# Altium schematic sheet z-probe.SchDoc
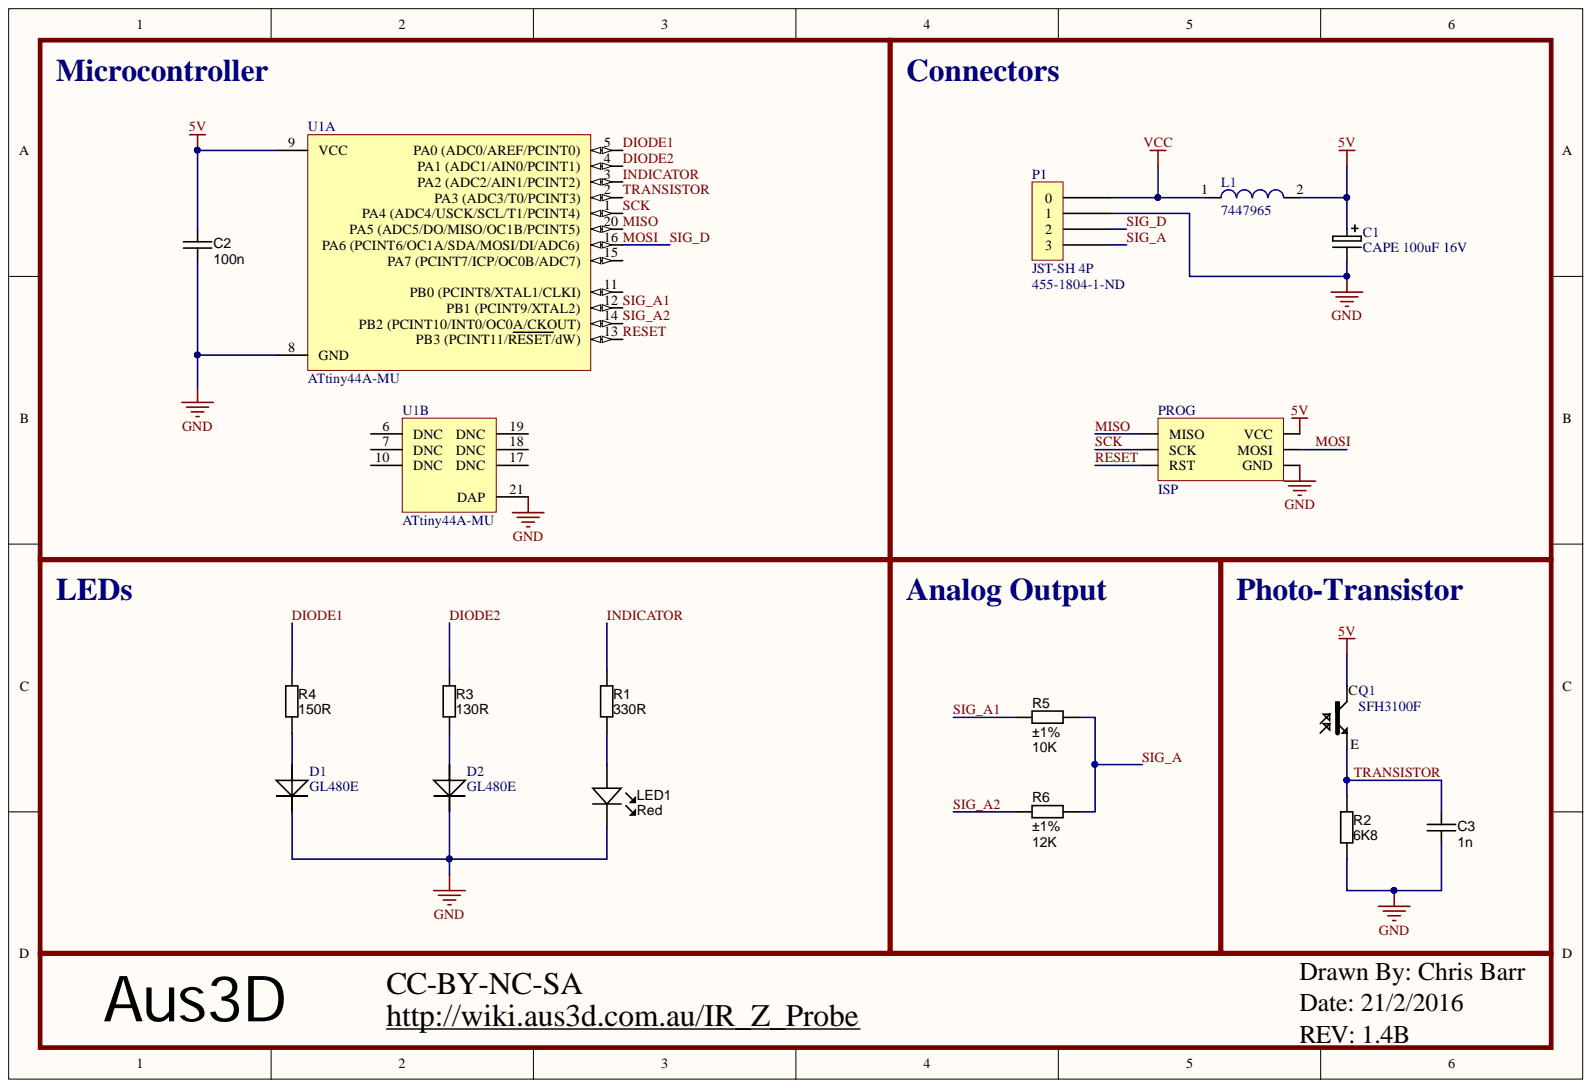
[diagram p0: z-probe.SchDoc]

## Parts
C1  CAPE 100uF 16V  [CAPE_PANASONIC_FTV]
C2  value 100n  [CAPC1608X90N]  (hidden comment: approved sub OK)
C3  value 1n  [CAPC1608X90N]  (hidden comment: approved sub OK)
D1  GL480E  [LED-2.54spacing]
D2  GL480E  [LED-2.54spacing]
L1  7447965  [SMD-0603]
LED1  Red  [LED-0603-RED]
P1  JST-SH 4P  Supplier Part Number 1: 455-1804-1-ND  [SM04B-SRSS-TB]
PROG  ISP  [ICSP Pads]
Q1  SFH3100F  [SFH3100F-footprint]
R1  value 330R  [RESC1608X55N]  (hidden comment: Thick Film)
R2  value 6K8  [RESC1608X55N]  (hidden comment: Thick Film)
R3  value 130R  [RESC1608X55N]  (hidden comment: Thick Film)
R4  value 150R  [RESC1608X55N]  (hidden comment: Thick Film)
R5  value 10K  Tolerance: ±1%  [RESC1608X55N]  (hidden comment: Thick Film)
R6  value 12K  Tolerance: ±1%  [RESC1608X55N]  (hidden comment: Thick Film)
U1  ATtiny44A-MU  [20M1_N]  (parts A,B)

## Nets
5V: C1.1 C2.2 L1.2 PROG.1(VCC) Q1.1 U1.9(VCC)
GND: C1.0 C2.1 C3.2 D1.1 D2.1 LED1.K P1.1 PROG.5(GND) R2.1 U1.8(GND) U1.21(DAP)
VCC: L1.1 P1.0
DIODE1: R4.2 U1.5
DIODE2: R3.2 U1.4(PA1_(ADC1/AIN0/PCINT1))
INDICATOR: R1.1 U1.3(PA2_(ADC2/AIN1/PCINT2))
MISO: PROG.0(MISO) U1.20(PA5_(ADC5/DO/MISO/OC1B/PCINT5))
MOSI: P1.2 PROG.3(MOSI) U1.16(PA6_(PCINT6/OC1A/SDA/MOSI/DI/ADC6))
RESET: PROG.4(RST) U1.13(PB3_(PCINT11/RESET/dW))
SCK: PROG.2(SCK) U1.1(PA4_(ADC4/USCK/SCL/T1/PCINT4))
SIG_A: P1.3 R5.2 R6.2
SIG_A1: R5.1 U1.12(PB1_(PCINT9/XTAL2))
SIG_A2: R6.1 U1.14(PB2_(PCINT10/INT0/OC0A/CKOUT))
TRANSISTOR: C3.1 Q1.2 R2.2 U1.2(PA3_(ADC3/T0/PCINT3))
NetD1_2: D1.2 R4.1
NetD2_2: D2.2 R3.1
NetLED1_A: LED1.A R1.2

Not connected: U1 6(DNC) 7(DNC) 10(DNC) 11(PB0_(PCINT8/XTAL1/CLKI)) 15(PA7_(PCINT7/ICP/OC0B/ADC7)) 17(DNC) 18(DNC) 19(DNC)

## Library data of the parts
C2 = CAPC_100n_0603_X7R_50V_10%: Capacitor SMD Cer 100nF 10% 50V X7R 0603; Manufacturer 1: AVX Corporation; Manufacturer Part Number 1: 06035C104KAT2A; Material: ceramic; Ratings: 50V; X7R; SCDType: spec; Component Kind: Standard; ComponentLink1Description: http://www.avx.com/docs/Catalogs/cx7r.pdf; ComponentLink1URL: http://www.avx.com/docs/Catalogs/cx7r.pdf; ComponentLink2Description: http://product.tdk.com/capacitor/mlcc/en/documents/mlcc_commercial_general_en.pdf; ComponentLink2URL: http://product.tdk.com/capacitor/mlcc/en/documents/mlcc_commercial_general_en.pdf; Library Name: PBL - Capacitors.SCHLIB; Manufacturer 2: TDK Corporation; Manufacturer Part Number 2: C1608X7R1H104K; Package / Case: 0603 (1608 Metric); Supplier 1: Digi-Key; Supplier 2: Digi-Key; Supplier Part Number 1: 478-5052-1-ND; Supplier Part Number 2: 445-1314-1-ND; Tolerance: ±10%
C3 = CAPC_1n_0603_X7R_100V_10%: Capacitor SMD Cer 1nF 10% 100V X7R 0603; Manufacturer 1: Murata Electronics North America; Manufacturer Part Number 1: GCM188R72A102KA37D; Material: ceramic; Ratings: 100V; X7R; SCDType: spec; Component Kind: Standard; ComponentLink1Description: http://search.murata.co.jp/Ceramy/image/img/PDF/ENG/GCM188R72A102KA37.pdf; ComponentLink1URL: http://search.murata.co.jp/Ceramy/image/img/PDF/ENG/GCM188R72A102KA37.pdf; Library Name: PBL - Capacitors.SCHLIB; Operating Temperature: -55°C ~ 125°C; Package / Case: 0603 (1608 metric); Size / Dimension: 0.063" L x 0.031" W (1.60mm x 0.80mm); Supplier 1: Digi-Key; Supplier Part Number 1: 490-4780-1-ND; Thickness (Max): 0.035" (0.90mm); Tolerance: ±10%
L1 = 7447965: SMD-Multilayer Inductor WE-MI, L = 1.00 µH; Inductance: 1.00 µH; Component Kind: Standard; ComponentLink1Description: Manufacturer URL; ComponentLink1URL: http://www.we-online.com; ComponentLink2Description: Datasheet; ComponentLink2URL: http://www.we-online.de/katalog/media/pdf/7447965.pdf; DatasheetVersion: ver 5, Oct-2004; Library Name: Wuerth Elektronik Filter Inductor.IntLib; PackageDescription: Chip Inductor, 2-Leads, Body 1.6 x 0.8 mm; PackageReference: SMD-0603; PackageVersion: 2009; Supplier: https://au.rs-online.com/web/p/multilayer-surface-mount-inductors/6041473/
LED1 = LED_SMD_Red_0603_220mcd: LED 0603 SMD Hyper Red 630nm 220mcd 20mA; Manufacturer 1: Kingbright Company LLC; Manufacturer Part Number 1: APT1608SURCK; Material: AlGaInP on GaAs; Ratings: 220 mcd; 630 nm; SCDType: source; Voltage - Forward (Vf) @ 10mA: 1.9V; Voltage - Forward (Vf) Typ: 1.95V; Component Kind: Standard; ComponentLink1Description: http://www.us.kingbright.com/images/catalog/SPEC/APT1608SURCK.pdf; ComponentLink1URL: http://www.us.kingbright.com/images/catalog/SPEC/APT1608SURCK.pdf; Library Name: PBL - Light Sources.SCHLIB; Package / Case: 0603 (1608 metric); Supplier 1: Digi-Key; Supplier Part Number 1: 754-1123-1-ND
P1 = SM04B-SRSS-TB: Component Kind: Standard; Library Name: Schlib1.SchLib; Supplier 1: Digi-Key
PROG = ISP Header: Component Kind: Standard; Library Name: Schlib1.SchLib
Q1 = SFH3100F: GL480E; Component Kind: Standard; Library Name: Schlib1.SchLib; Supplier: http://au.rs-online.com/web/p/phototransistors/6548738/
R1 = RES_330R_0603_5%: Resistor SMD chip 330 Ohm 0.1W 5% 0603; Manufacturer 1: Vishay Dale; Manufacturer Part Number 1: CRCW0603330RJNEA; Ratings: 1/10 W; SCDType: spec; Component Kind: Standard; ComponentLink1Description: http://www.vishay.com/doc?20035; ComponentLink1URL: http://www.vishay.com/doc?20035; ComponentLink2Description: http://www.yageo.com/documents/recent/PYu-RC0603_51_RoHS_L_4.pdf; ComponentLink2URL: http://www.yageo.com/documents/recent/PYu-RC0603_51_RoHS_L_4.pdf; Library Name: PBL - Resistors.SCHLIB; Manufacturer 2: Yageo; Manufacturer Part Number 2: RC0603JR-07330RL; Material: Thick Film; Package / Case: 0603 (1608 metric); Supplier: http://au.rs-online.com/web/p/surface-mount-fixed-resistors/6790226/; Supplier 1: Digi-Key; Supplier 2: Digi-Key; Supplier Part Number 1: 541-330GCT-ND; Supplier Part Number 2: 311-330GRCT-ND; Tolerance: ±5%
R2-R4 = RES_2K2_0603_5%: Resistor SMD chip 2.2k Ohm 0.1W 5% 0603; Manufacturer 1: Yageo; Manufacturer Part Number 1: RC0603JR-072K2L; Ratings: 1/10 W; SCDType: spec; Temperature Coefficient: ±100ppm/°C; Component Kind: Standard; ComponentLink1Description: http://www.yageo.com/documents/recent/PYu-RC0603_51_RoHS_L_4.pdf; ComponentLink1URL: http://www.yageo.com/documents/recent/PYu-RC0603_51_RoHS_L_4.pdf; ComponentLink2Description: http://www.samsungsem.com/servlet/FileDownload?filename=productcatalog/pdf/Chip_Resistor.pdf; ComponentLink2URL: http://www.samsungsem.com/servlet/FileDownload?filename=productcatalog/pdf/Chip_Resistor.pdf; ComponentLink3Description: http://www.seielect.com/Catalog/SEI-RMCF_RMCP.pdf; ComponentLink3URL: http://www.seielect.com/Catalog/SEI-RMCF_RMCP.pdf; ComponentLink4Description: http://rohmfs.rohm.com/en/products/databook/datasheet/passive/resistor/chip_resistor/mcr_g.pdf; ComponentLink4URL: http://rohmfs.rohm.com/en/products/databook/datasheet/passive/resistor/chip_resistor/mcr_g.pdf; ComponentLink5Description: http://industrial.panasonic.com/www-cgi/jvcr13pz.cgi?E+PZ+3+AOA0001+ERJ3GEYJ222V+7+WW; ComponentLink5URL: http://industrial.panasonic.com/www-cgi/jvcr13pz.cgi?E+PZ+3+AOA0001+ERJ3GEYJ222V+7+WW; Library Name: PBL - Resistors.SCHLIB; Manufacturer 2: Samsung Electro-Mechanics America, Inc; Manufacturer 3: Stackpole Electronics Inc; Manufacturer 4: Rohm Semiconductor; Manufacturer 5: Panasonic Electronic Components; Manufacturer Part Number 2: RC1608J222CS; Manufacturer Part Number 3: RMCF0603JT2K20; Manufacturer Part Number 4: MCR03ERTJ222; Manufacturer Part Number 5: ERJ-3GEYJ222V; Material: Thick Film; Package / Case: 0603 (1608 metric); RoHS 1: RoHS Compliant; RoHS 2: RoHS Compliant; RoHS 3: RoHS Compliant; RoHS 4: RoHS Compliant; RoHS 5: RoHS Compliant; Supplier 1: Digi-Key; Supplier 2: Digi-Key; Supplier 3: Digi-Key; Supplier 4: Digi-Key; Supplier 5: Digi-Key; Supplier Part Number 1: 311-2.2KGRDKR-ND; Supplier Part Number 2: 1276-5070-6-ND; Supplier Part Number 3: RMCF0603JT2K20DKR-ND; Supplier Part Number 4: RHM2.2KCGDKR-ND; Supplier Part Number 5: P2.2KGDKR-ND; Tolerance: ±5%
  R2: Supplier: http://au.rs-online.com/web/p/surface-mount-fixed-resistors/8102303/
  R3: Supplier: http://au.rs-online.com/web/p/surface-mount-fixed-resistors/8102129/
  R4: Supplier: http://au.rs-online.com/web/p/surface-mount-fixed-resistors/6789784/
R5 R6 = RES_10K_0603_1%: Resistor SMD chip 10k Ohm 0.1W 1% 0603; Manufacturer 1: Yageo; Manufacturer Part Number 1: RC0603FR-0710KL; Ratings: 1/10W; SCDType: spec; Component Kind: Standard; Library Name: PBL - Resistors.SCHLIB; Package / Case: 0603 (1608 Metric); Supplier 1: Digi-Key; Supplier Part Number 1: 311-10.0KHRCT-ND
U1 = ATtiny44A-MU: 8-bit AVR Microcontroller,  20MHz, 1.8-5.5V, 4KB Flash, 256 Bytes EEPROM, 256 Bytes SRAM, 20-pin MLF, Industrial Grade (-40°C to 85°C), Pb-Free; Code_JEDEC: MO-220WGGD-5; Component Kind: Standard; ComponentLink1Description: Manufacturer URL; ComponentLink1URL: http://www.atmel.com/; ComponentLink2Description: ATtiny24A/44A/84A Datasheet; ComponentLink2URL: http://www.atmel.com/dyn/resources/prod_documents/doc8183.pdf; ComponentLink3Description: ATtiny24A/44A/84A Summary; ComponentLink3URL: http://www.atmel.com/dyn/resources/prod_documents/8183S.pdf; DatasheetVersion: revD, Apr-2011; Library Name: Atmel Microcontroller tinyAVR.IntLib; PackageDescription: 20-pad, 4 x 4 x 0.8 mm Body, Lead Pitch 0.50 mm, 2.6 mm Exposed Pad, Micro Lead Frame Package (MLF); PackageReference: 20M1; PackageVersion: revA, Oct-2004
C1 = CAPE 100uF 16V: Component Kind: Standard; Library Name: Schlib1.SchLib; Supplier 1: Digi-Key; Supplier Part Number 1: P15086TR-ND
D1 D2 = GL480E: Component Kind: Standard; Description: GL480E; Library Name: Schlib1.SchLib
  D2: Supplier: http://au.rs-online.com/web/p/ir-leds/0577538/
